# Altium schematic sheet iRoot-amp-1.8.SchDoc(iRoot-amp-1.8)
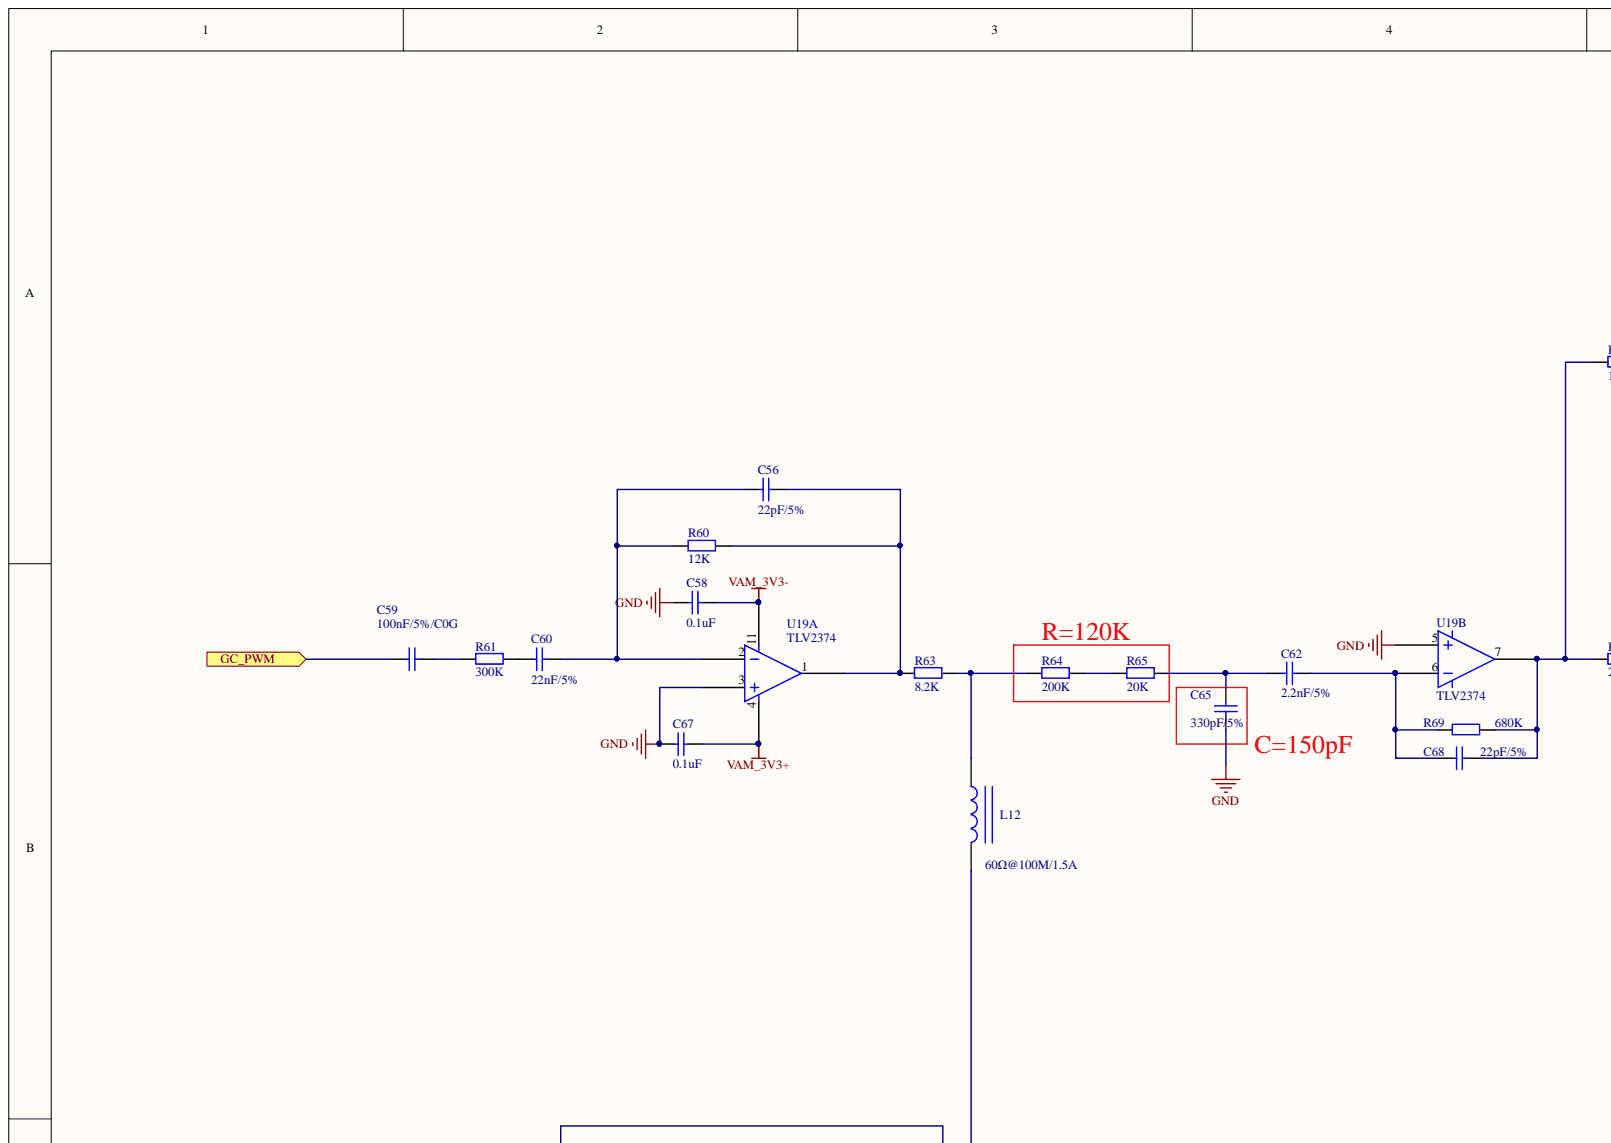
[diagram p0: iRoot-amp-1.8.SchDoc, top left]
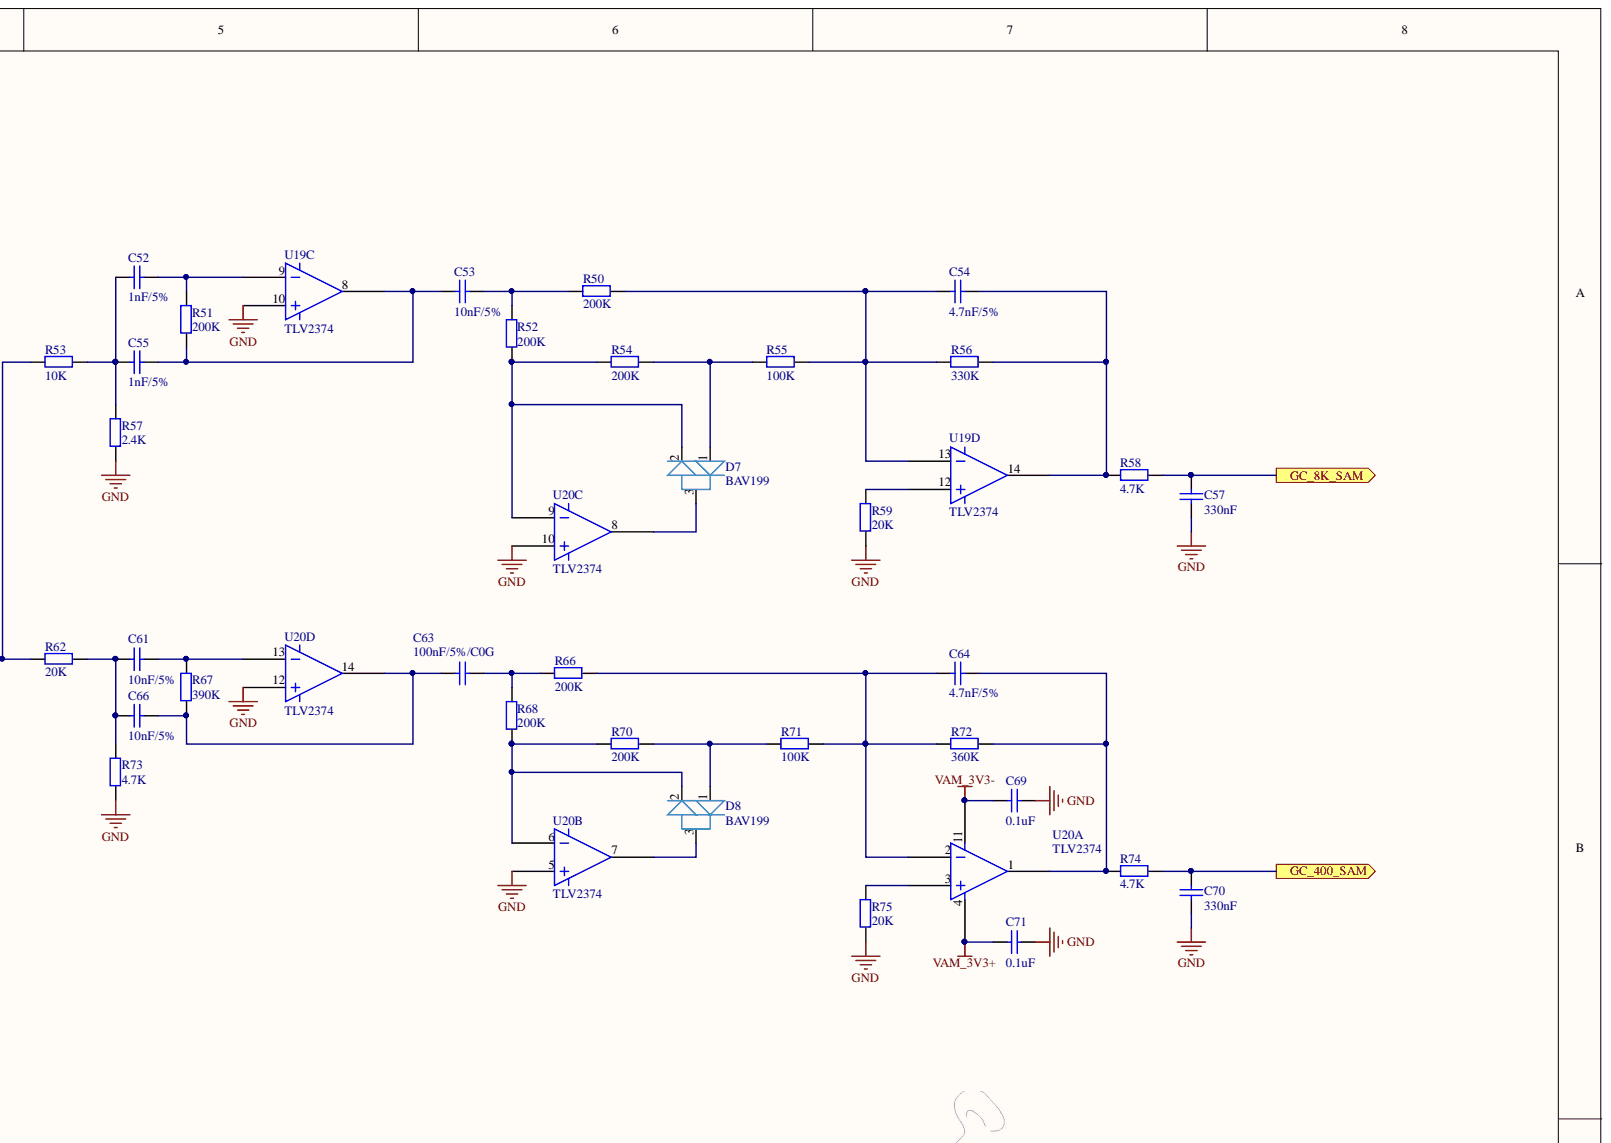
[diagram p1: iRoot-amp-1.8.SchDoc, top right]
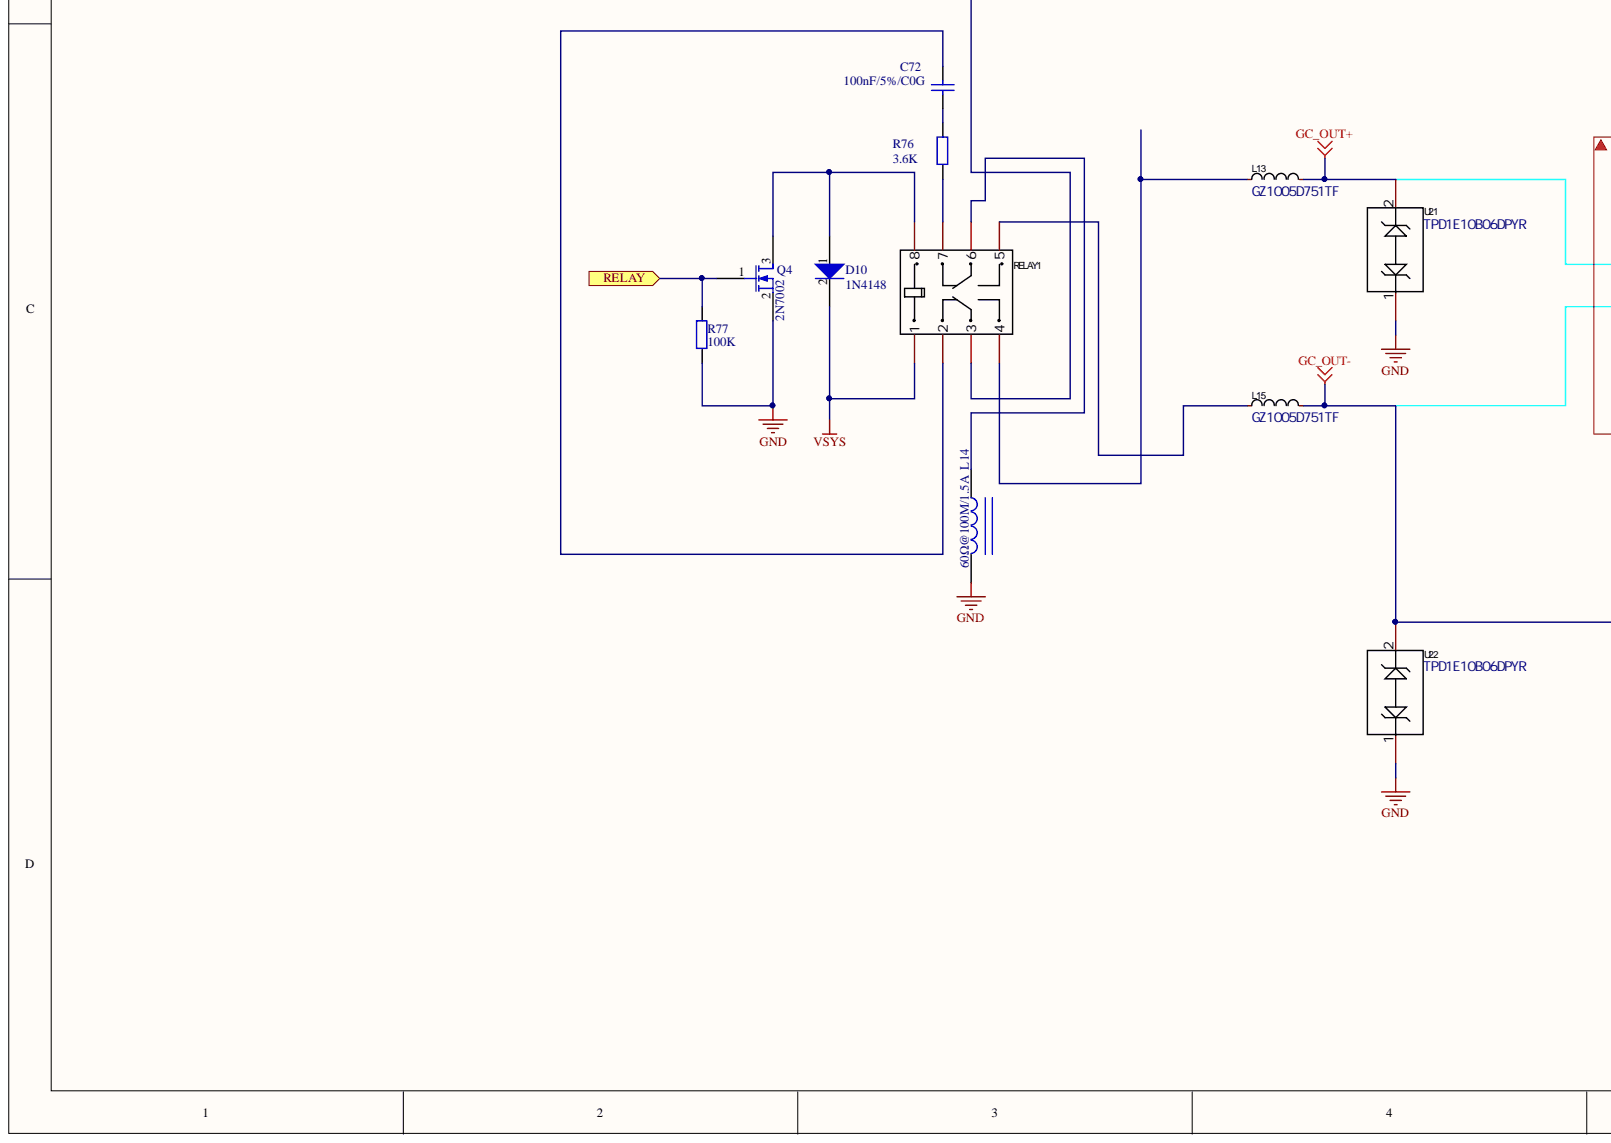
[diagram p2: iRoot-amp-1.8.SchDoc, bottom left]
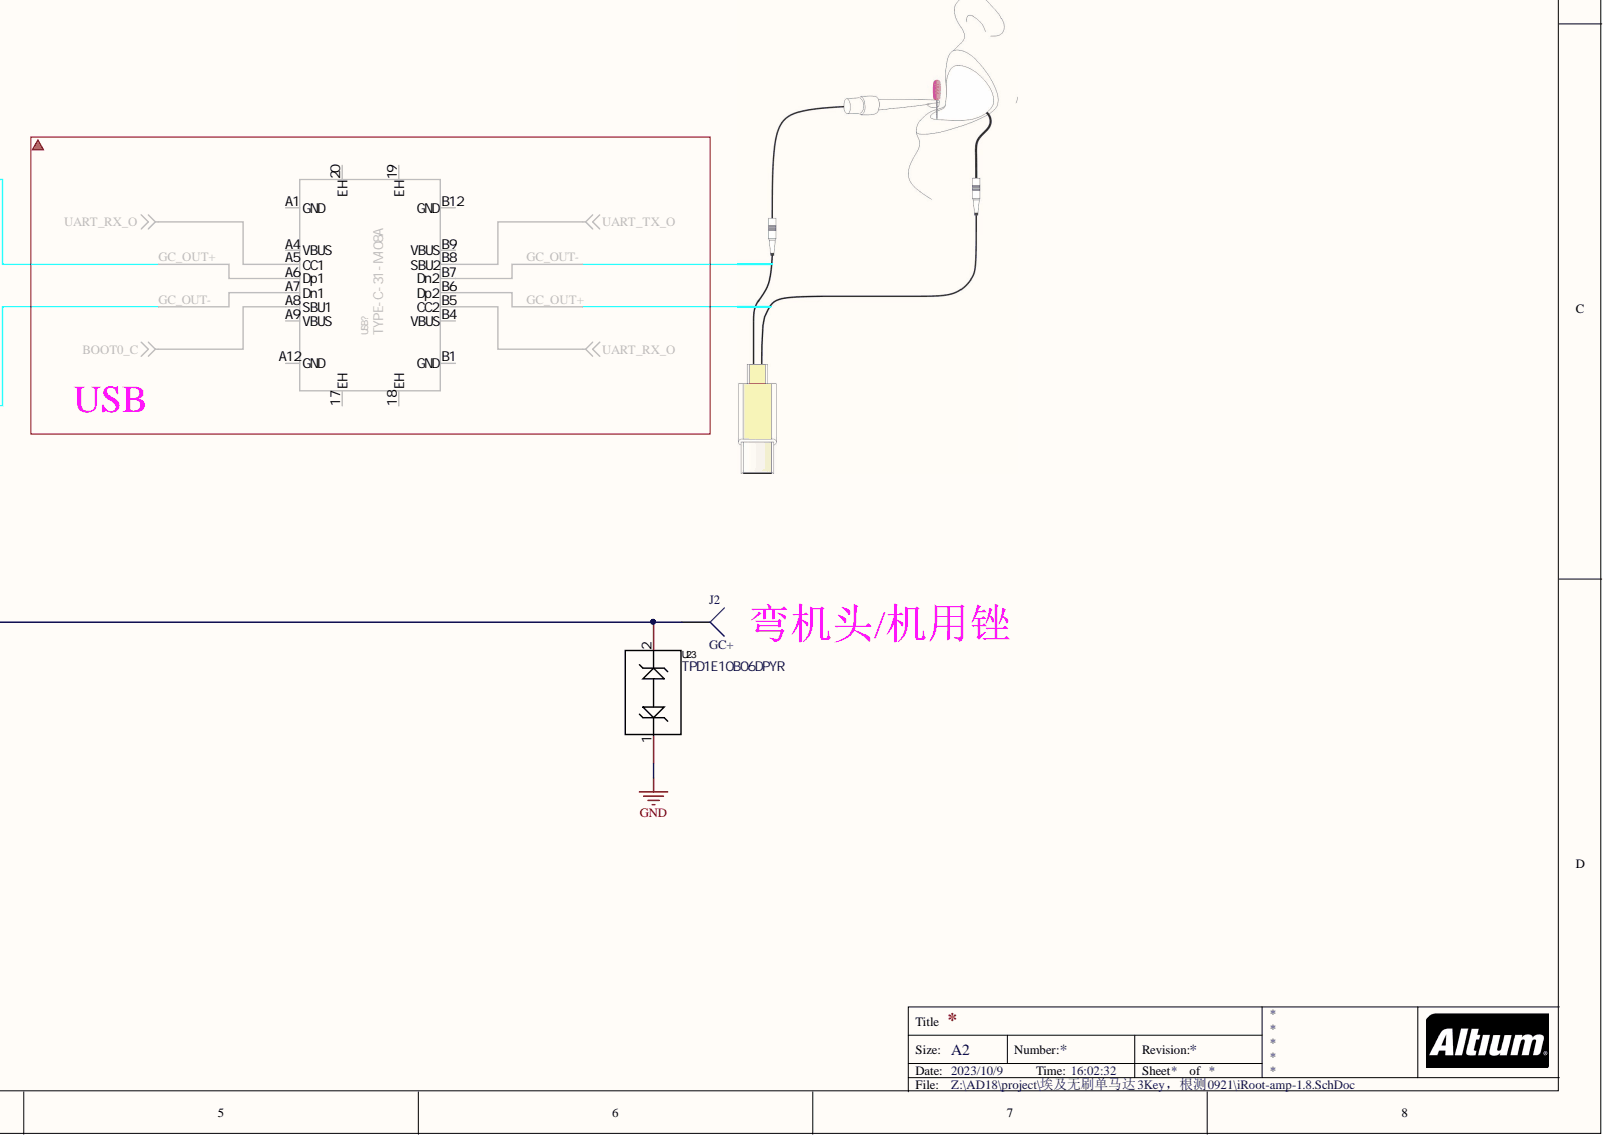
[diagram p3: iRoot-amp-1.8.SchDoc, bottom right]

## Parts
C52  1nF/5%  [0402]
C53  10nF/5%  [0603]
C54  4.7nF/5%  [0603]
C55  1nF/5%  [0402]
C56  22pF/5%  [0402]
C57  330nF  [0402]
C58  0.1uF  [0402]
C59  100nF/5%/C0G  [C1206]
C60  22nF/5%  [0805]
C61  10nF/5%  [0603]
C62  2.2nF/5%  [0603]
C63  100nF/5%/C0G  [C1206]
C64  4.7nF/5%  [0603]
C65  330pF/5%  [0402]
C66  10nF/5%  [0603]
C67  0.1uF  [0402]
C68  22pF/5%  [0402]
C69  0.1uF  [0402]
C70  330nF  [0402]
C71  0.1uF  [0402]
C72  100nF/5%/C0G  [C1206]
D7  BAV199  [SOT-23]
D8  BAV199  [SOT-23]
D10  1N4148  [SOD523]
J2  GC+  [PIN1]
L12  60Ω@100M/1.5A  [0402]
L13  GZ1005D751TF  [L0402]
L14  60Ω@100M/1.5A  [L0402]
L15  GZ1005D751TF  [L0402]
Q4  2N7002  [SOT-23(SOT-23-3)]
R50  200K  [0402]
R51  200K  [0402]
R52  200K  [0402]
R53  10K  [0402]
R54  200K  [0402]
R55  100K  [0402]
R56  330K  [0402]
R57  2.4K  [0402]
R58  4.7K  [0402]
R59  20K  [0402]
R60  12K  [0402]
R61  300K  [0402]
R62  20K  [0402]
R63  8.2K  [0402]
R64  200K  [0402]
R65  20K  [0402]
R66  200K  [0402]
R67  390K  [0402]
R68  200K  [0402]
R69  680K  [0402]
R70  200K  [0402]
R71  100K  [0402]
R72  360K  [0402]
R73  4.7K  [0402]
R74  4.7K  [0402]
R75  20K  [0402]
R76  3.6K  [0402]
R77  100K  [0402]
RELAY1  HFD4/5-SR  [RELAY-SMD_HFD4-5-SR]  (value not drawn)
U19  TLV2374  [TSSOP-14]  (parts A,B,C,D)
U20  TLV2374  [TSSOP-14]  (parts A,B,C,D)
U21  TPD1E10B06DPYR  [X1SON-2_L1.0-W0.6-P0.65-BI]
U22  TPD1E10B06DPYR  [X1SON-2_L1.0-W0.6-P0.65-BI]
U23  TPD1E10B06DPYR  [X1SON-2_L1.0-W0.6-P0.65-BI]

## Ports
GC_8K_SAM, GC_400_SAM, GC_PWM, RELAY

## Nets
GC_OUT+: L13.1 U21.2
GC_OUT-: J2.1 L15.1 U22.2 U23.2
GND: C57.1 C58.1 C65.1 C67.1 C69.2 C70.2 C71.2 L14.2 Q4.2 R57.2 R59.1 R73.2 R75.2 R77.1 U19.3 U19.5 U19.10 U20.5 U20.10 U20.12 U21.1 U22.1 U23.1
VAM_3V3+: C67.2 C71.1 U19.4 U20.4
VAM_3V3-: C58.2 C69.1 U19.11 U20.11
VSYS: D10.2 RELAY1.1
GC_8K_SAM: C57.2 R58.1 ; port GC_8K_SAM
GC_400_SAM: C70.1 R74.1 ; port GC_400_SAM
GC_PWM: C59.1 ; port GC_PWM
RELAY: Q4.1 R77.2 ; port RELAY
NetC52_1: C52.1 C55.1 R53.1 R57.1
NetC52_2: C52.2 R51.1 U19.9
NetC53_1: C53.1 R50.2 R52.1
NetC53_2: C53.2 C55.2 R51.2 U19.8
NetC54_1: C54.1 R50.1 R55.1 R56.2 U19.13
NetC54_2: C54.2 R56.1 R58.2 U19.14
NetC56_1: C56.1 C60.2 R60.2 U19.2
NetC56_2: C56.2 R60.1 R63.2 U19.1
NetC59_2: C59.2 R61.2
NetC60_1: C60.1 R61.1
NetC61_1: C61.1 C66.1 R62.1 R73.1
NetC61_2: C61.2 R67.1 U20.13
NetC62_1: C62.1 C65.2 R65.2
NetC62_2: C62.2 C68.1 R69.2 U19.6
NetC63_1: C63.1 C66.2 R67.2 U20.14
NetC63_2: C63.2 R66.2 R68.1
NetC64_1: C64.1 R66.1 R71.1 R72.2 U20.2
NetC64_2: C64.2 R72.1 R74.2 U20.1
NetC68_2: C68.2 R53.2 R62.2 R69.1 U19.7
NetC72_1: C72.1 R76.1
NetC72_2: C72.2 RELAY1.2
NetD7_1: D7.1 R54.1 R55.2
NetD7_2: D7.2 R52.2 R54.2 U20.9
NetD7_3: D7.3 U20.8
NetD8_1: D8.1 R70.1 R71.2
NetD8_2: D8.2 R68.2 R70.2 U20.6
NetD8_3: D8.3 U20.7
NetD10_1: D10.1 Q4.3 RELAY1.8
NetL12_1: L12.1 R63.1 R64.1
NetL12_2: L12.2 RELAY1.3
NetL13_2: L13.2 RELAY1.4
NetL14_1: L14.1 RELAY1.6
NetL15_2: L15.2 RELAY1.5
NetR59_2: R59.2 U19.12
NetR64_2: R64.2 R65.1
NetR75_1: R75.1 U20.3
NetR76_2: R76.2 RELAY1.7

## Library data of the parts
C52 C55 = 0603 10uF (1: 贴片电容 5% C0G 50V; ManufacturerNumber: 0402CG102J500NT; Manufacturer: 风华高科; SuppliersPartNumber: C53547; Library Name: Cap.SchLib; Component Kind: Standard
C53 C61 C66 = 0603 10uF (1: 贴片电容 5% C0G 50V; ManufacturerNumber: 0603CG103J500NT; Manufacturer: 风华高科; SuppliersPartNumber: C82282; Library Name: Cap.SchLib; Component Kind: Standard
C54 C64 = 0603 10uF (1: 贴片电容 5% C0G 50V; ManufacturerNumber: GRM1885C1H472JA01D; Manufacturer: muRata; SuppliersPartNumber: C85980; Library Name: Cap.SchLib; Component Kind: Standard
C56 = 0603 10uF (1: 贴片电容 5% C0G 50V; ManufacturerNumber: 0402CG220J500NT; Manufacturer: 风华高科; SuppliersPartNumber: C1555; Library Name: Cap.SchLib; Component Kind: Standard
C57 C70 = 0603 10uF (1: 贴片电容 10% X7R 16V; ManufacturerNumber: 0402B334K160NT; Manufacturer: FH(风华); SuppliersPartNumber: C301942; Library Name: Cap.SchLib; Component Kind: Standard
C58 C67 C69 C71 = 0603 10uF (1: 贴片电容 10% X7R 16V; ManufacturerNumber: CL05B104KO5NNND; Manufacturer: SAMSUNG; SuppliersPartNumber: C134153; Library Name: Cap.SchLib; Component Kind: Standard
C59 C63 C72 = Cap Semi: Capacitor (Semiconductor SIM Model); Value: 100pF; Simulation: CAP; Signal Integrity: Cap Semi; Published: 8-Jun-2000; PackageReference: C1206; PackageDescription: Chip Capacitor; Library Name: Miscellaneous Devices.IntLib; LatestRevisionNote: IPC-7351 Footprint Added.; LatestRevisionDate: 29-May-2009; Component Kind: Standard; Publisher: Altium Limited
C60 = 0603 10uF (1: 贴片电容 5% C0G 50V; ManufacturerNumber: 0805CG223J500NT; Manufacturer: 风华高科; SuppliersPartNumber: C63850; Library Name: Cap.SchLib; Component Kind: Standard
C62 = 0603 10uF (1: 贴片电容 5% C0G 50V; ManufacturerNumber: GRM1885C1H222JA01D; Manufacturer: muRata; SuppliersPartNumber: C77033; Library Name: Cap.SchLib; Component Kind: Standard
C65 = 0603 10uF (1: 贴片电容 5% C0G 50V; ManufacturerNumber: 0402CG331J500NT; Manufacturer: 风华高科; SuppliersPartNumber: C190579; Library Name: Cap.SchLib; Component Kind: Standard
C68 = 0603 10uF (1: 贴片电容 5%C0G 50V; ManufacturerNumber: 0402CG220J500NT; Manufacturer: FH(风华); SuppliersPartNumber: C1555; Library Name: Cap.SchLib; Component Kind: Standard
D7 D8 = BA: 开关二极管  70V 215mA VF=1.25V@150mA; Manufacturer: CJ江苏长电; SuppliersPartNumber: C68971; ManufacturerNumber: BAV199; Library Name: bm190409.SCHLIB; Component Kind: Standard
D10 = SO: 开关二极管 75V 150mA trr=4ns VF=1.25V@150mA PD=400mW; ManufacturerNumber: 1N4148WT; Manufactu: CJ江苏长电; SuppliersPartNumber: C68948; Library Name: Diode.SchLib; Component Kind: Standard
J2 = Socket: Signal Integrity: Connector; Library Name: Miscellaneous Connectors.IntLib; LatestRevisionNote: Re-released for DXP Platform.; LatestRevisionDate: 17-Jul-2002; Description: Socket; Component Kind: Standard; Publisher: Altium Limited
L12 L14 = 1206 4.7uH  10%: 贴片磁珠 60Ω ±25% @100MHz 1.5A; ManufacturerNumber: UPZ1005D600-1R5TF; Manufacturer: Sunlord; SuppliersPartNumber: C98300; Library Name: IUD.SchLib; Component Kind: Standard
L13 L15 = GZ1005D751TF: 磁珠 750Ω@100MHz  ±25%   直流电阻(RDC) 1ohm  额定电流 100mA; Manufacturer: Sunlord(顺络); DC Resistance: 1Ω; Convert to PCB: yes; Circuits: 1; Tolerance: ±25%; Symbol: GZ1005D751TF; Supplier Part: C96684; Supplier Footprint: 0402; Supplier: LCSC; PCB3D: L0402_L1.0-W0.5-H0.5_BEAD; Name: GZ1005D751TF; Manufacturer Part: GZ1005D751TF; Library Name: HiPin_Library_AD.IntLib; LCSC Part Name: 750Ω@100MHz ±25%; JLCPCB Part Class: Extended Part; Impedance @ Frequency: 750Ω@100MHz; Device: GZ1005D751TF; Datasheet: https://atta.szlcsc.com/upload/public/pdf/source/20210830/355EA7C0FAC5394FC0FE3F17C770C20A.pdf; Current Rating: 100mA; Component Kind: Standard; Add into BOM: yes
Q4 = MOSFET-N: NMOS 60V 0.115A 7Ω@10V; Manufacturer: CJ江苏长电; SuppliersPartNumber: C8545; ManufacturerNumber: 2N7002; Library Name: Miscellaneous Devices.IntLib; Component Kind: Standard
R50-R52 R54 R64 R66 R68 R70 = 0603 49: 贴片电阻 1% 1/16W 100ppm; ManufacturerNumber: RC0402FR-07200KL; Manufacturer: YAGEO; SuppliersPartNumber: C114763; Library Name: RES.SchLib; Component Kind: Standard
R53 = 0603 49: 贴片电阻 1% 1/16W 200ppm; ManufacturerNumber: RC0402FR-0710KL; Manufacturer: YAGEO; SuppliersPartNumber: C60490; Library Name: RES.SchLib; Component Kind: Standard
R55 R71 = 0603 49: 贴片电阻 1% 1/16W 100ppm; ManufacturerNumber: RC0402FR-07100KL; Manufacturer: YAGEO; SuppliersPartNumber: C60491; Library Name: RES.SchLib; Component Kind: Standard
R56 = 0603 49: 贴片电阻 1% 1/16W 200ppm; ManufacturerNumber: RC0402FR-07330KL; Manufacturer: YAGEO; SuppliersPartNumber: C138006; Library Name: RES.SchLib; Component Kind: Standard
R57 = 0603 49: 贴片电阻 1% 1/16W 100ppm; ManufacturerNumber: RC0402FR-072K4L; Manufacturer: YAGEO; SuppliersPartNumber: C112296; Library Name: RES.SchLib; Component Kind: Standard
R58 R73 R74 = 0603 49: 贴片电阻 1% 1/16W 100ppm; ManufacturerNumber: RC0402FR-074K7L; Manufacturer: YAGEO; SuppliersPartNumber: C105871; Library Name: RES.SchLib; Component Kind: Standard
R59 R62 R65 R75 = 0603 49: 贴片电阻 1% 1/16W 100ppm; ManufacturerNumber: RC0402FR-0720KL; Manufacturer: YAGEO; SuppliersPartNumber: C93942; Library Name: RES.SchLib; Component Kind: Standard
R60 = 0603 49: 贴片电阻 1% 1/16W 200ppm; ManufacturerNumber: RC0402FR-0712KL; Manufacturer: YAGEO; SuppliersPartNumber: C114760; Library Name: RES.SchLib; Component Kind: Standard
R61 = 0603 49: 贴片电阻 1% 1/16W 100ppm; ManufacturerNumber: RC0402FR-07300KL; Manufacturer: YAGEO; SuppliersPartNumber: C138011; Library Name: RES.SchLib; Component Kind: Standard
R63 = 0603 49: 贴片电阻 1% 1/16W 100ppm; ManufacturerNumber: RC0402FR-078K2L; Manufacturer: YAGEO; SuppliersPartNumber: C137929; Library Name: RES.SchLib; Component Kind: Standard
R67 = 0603 49: 贴片电阻 1% 1/16W 100ppm; ManufacturerNumber: RC0402FR-07390KL; Manufacturer: YAGEO; SuppliersPartNumber: C163467; Library Name: RES.SchLib; Component Kind: Standard
R69 = 0603 49: 贴片电阻 1% 1/16W 100ppm; ManufacturerNumber: RC0402FR-07680KL; Manufacturer: YAGEO; SuppliersPartNumber: C163456; Library Name: RES.SchLib; Component Kind: Standard
R72 = 0603 49: 贴片电阻 1% 1/16W 100ppm; ManufacturerNumber: RC0402FR-07360KL; Manufacturer: YAGEO; SuppliersPartNumber: C163471; Library Name: RES.SchLib; Component Kind: Standard
R76 R77 = 0603 49: 贴片电阻 1% 1/16W 100ppm; ManufacturerNumber: RC0402FR-073K6L; Manufacturer: YAGEO; SuppliersPartNumber: C137990; Library Name: RES.SchLib; Component Kind: Standard
RELAY1 = HFD4/5-SR: Manufacturer: HF(宏发); Release Time: 3ms; Pin Number: 8; Maximum Switching Voltage: 250V@AC；220V@DC; Maximum Switching Current: 2A; Convert to PCB: yes; Contact Resistance: 100mΩ; Contact Rating: 1A@30VDC；300mA@125VAC; Contact Form: 两组转换:2C(双刀双掷-转换); Coil Voltage: 5V; Coil Type: 极化-单线圈; Coil Resistance: 178Ω; Coil Rated Power: 140mW; Symbol: HFD4/5-S; Supplier Part: C64399; Supplier Footprint: SMD,6.5x10mm; Supplier: LCSC; PCB3D: RELAY-SMD_L10.0-W6.5-H5.7-LS7.5-P2.2; Operating Temperature: -40℃~+85℃; Operate Time: 3ms; Name: HFD4/5-SR; Manufacturer Part: HFD4/5-SR; Library Name: HiPin_Library_AD.IntLib; LCSC Part Name: 超小型5V继电器 双刀双掷; JLCPCB Part Class: Extended Part; Device: HFD4/5-SR; Datasheet: https://atta.szlcsc.com/upload/public/pdf/source/20170415/1492238463316.pdf; Contact Material: AgNi+镀金; Component Kind: Standard; Add into BOM: yes
U19 U20 = TL: LINMOS四运算放大器; ManufacturerNumber: TLV2374IPWR; Manufacturer: TI; Library Name: user.SchLib; Component Kind: Standard
U21-U23 = TPD1E10B06DPYR: 双向低速度 TVS  应用在不高于5V电源和GPIO口  反向截止电压(Vrwm) 5.5VMAX  击穿电压 6V  最大钳位电压 14V; Manufacturer: TI(德州仪器); Reverse Stand-Off Voltage (Vrwm): 5.5V@Max; Peak Pulse Power Dissipation (Ppp)@10/1000μs: 90W; Convert to PCB: yes; Symbol: TPD1E10B06DPYR; Supplier Part: C48260; Supplier Footprint: X1-SON-2(0.6x1); Supplier: LCSC; Peak Pulse Current (Ipp)@10/1000μs: -; PCB3D: X1SON-2_L1.0-W0.6-H0.4-P0.65; Name: TPD1E10B06DPYR; Maximum Clamping Voltage: 14V; Manufacturer Part: TPD1E10B06DPYR; Library Name: HiPin_Library_AD.IntLib; LCSC Part Name: Vrwm:5.5V@Max 90W; JLCPCB Part Class: Extended Part; Device: TPD1E10B06DPYR; Datasheet: https://atta.szlcsc.com/upload/public/pdf/source/20171128/C48260_15118627709021147421.pdf; Component Kind: Standard; Breakdown Voltage: 6V; Add into BOM: yes
